# Altium schematic sheet Filter_Section.SchDoc
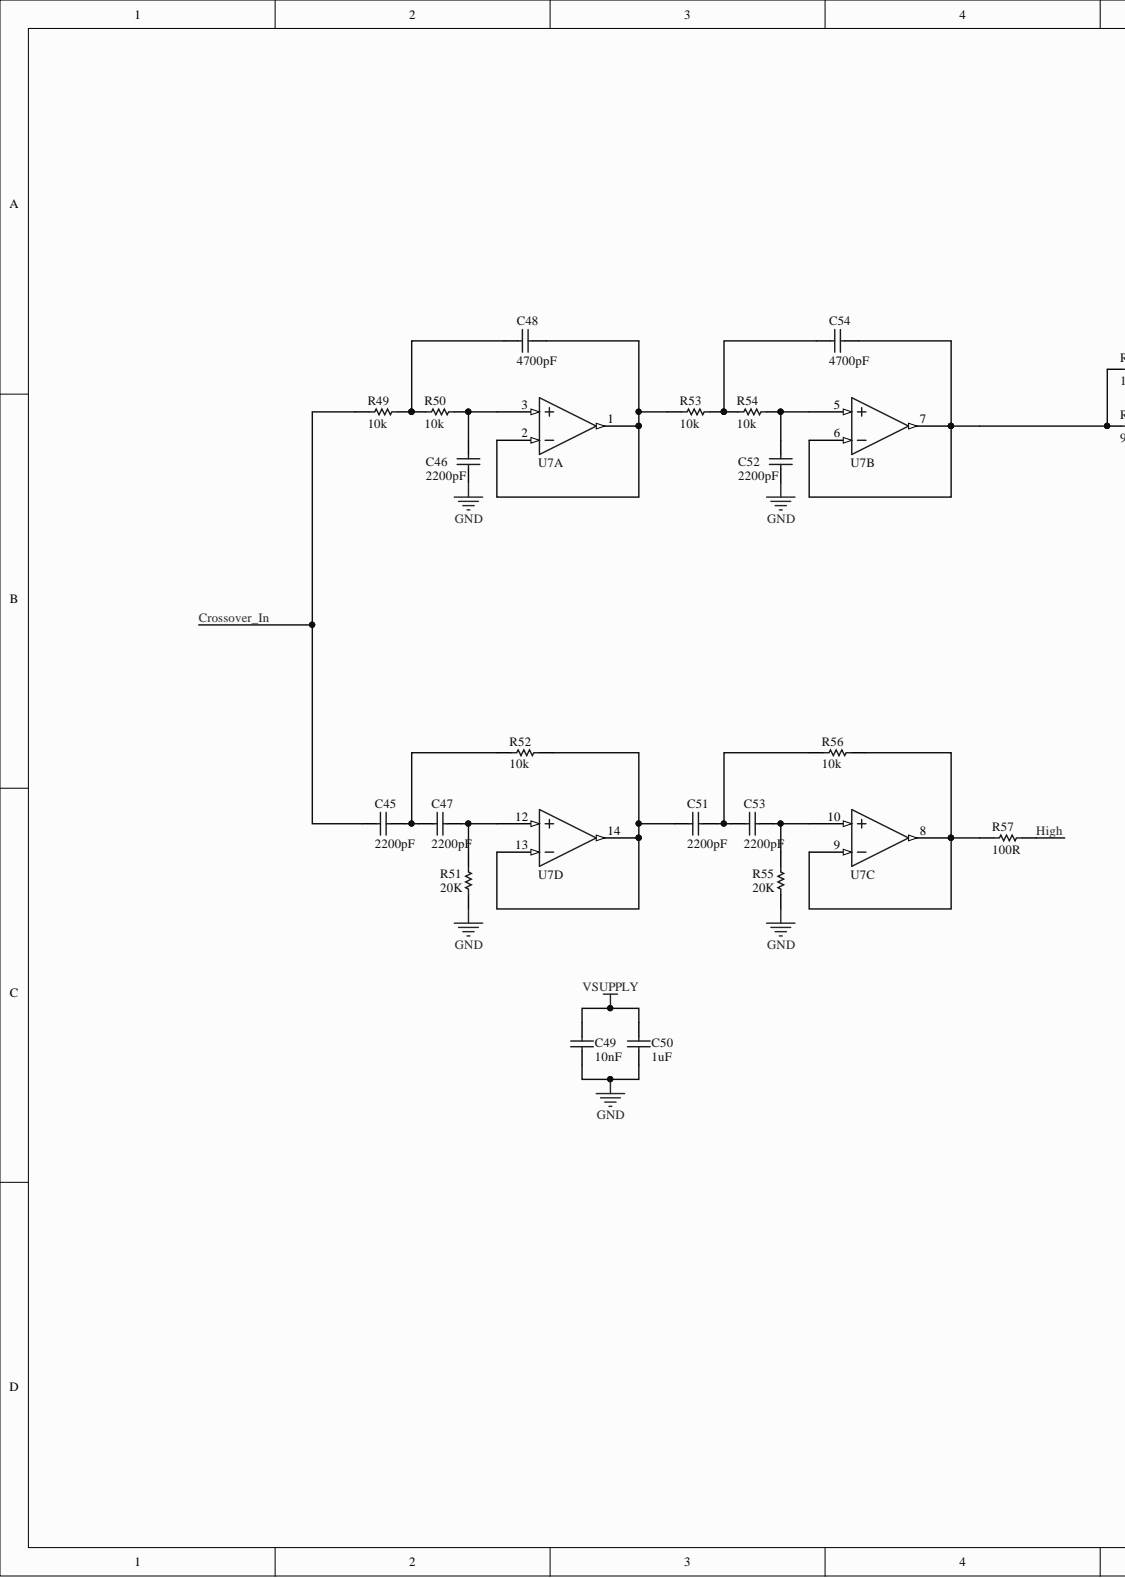
[diagram p0: Filter_Section.SchDoc, left]
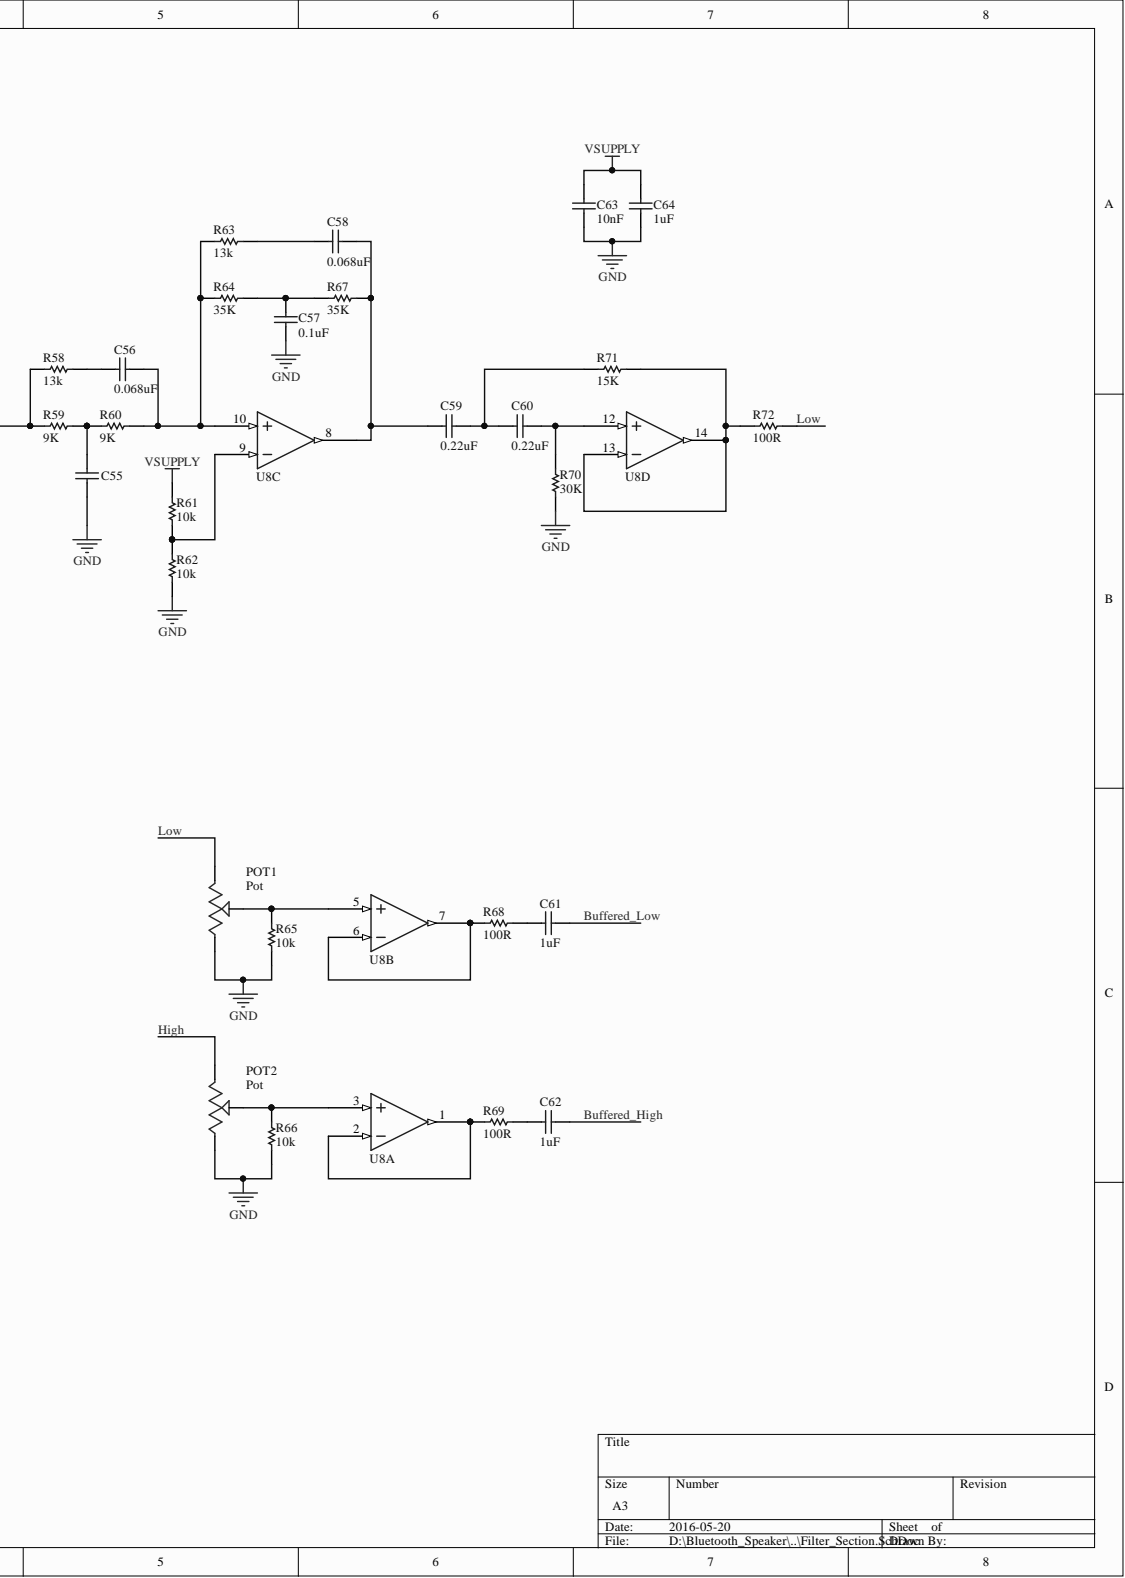
[diagram p1: Filter_Section.SchDoc, right]

## Parts
C45  2200pF  [CAPC1608X09N]
C46  2200pF  [CAPC1608X09N]
C47  2200pF  [CAPC1608X09N]
C48  4700pF  [CAPC1608X09N]
C49  10nF  [CAPC1608X09N]
C50  1uF  [CAPC1608X09N]
C51  2200pF  [CAPC1608X09N]
C52  2200pF  [CAPC1608X09N]
C53  2200pF  [CAPC1608X09N]
C54  4700pF  [CAPC1608X09N]
C55  0.39uF  [CAP Radial 5mm]  (value not drawn)
C56  0.068uF  [CAPC3216X18N]
C57  0.1uF  [CAPC3216X18N]
C58  0.068uF  [CAPC3216X18N]
C59  0.22uF  [CAP Radial 5mm]
C60  0.22uF  [CAP Radial 5mm]
C61  1uF  [CAP Radial 5mm]
C62  1uF  [CAP Radial 5mm]
C63  10nF  [CAPC1608X09N]
C64  1uF  [CAPC1608X09N]
POT1  Pot  [70543-0002]
POT2  Pot  [70543-0002]
R49  10k  [0603]
R50  10k  [0603]
R51  20K  [0603]
R52  10k  [0603]
R53  10k  [0603]
R54  10k  [0603]
R55  20K  [0603]
R56  10k  [0603]
R57  100R  [0603]
R58  13k  [0603]
R59  9K  [0603]
R60  9K  [0603]
R61  10k  [0603]
R62  10k  [0603]
R63  13k  [0603]
R64  35K  [0603]
R65  10k  [0603]
R66  10k  [0603]
R67  35K  [0603]
R68  100R  [0603]
R69  100R  [0603]
R70  30K  [0603]
R71  15K  [0603]
R72  100R  [0603]
U7  OPA4134UA  [SOIC127P600X175-14N]  (value not drawn)  (parts A,B,C,D)
U8  OPA4134UA  [SOIC127P600X175-14N]  (value not drawn)  (parts A,B,C,D)

## Nets
GND: C46.1 C49.1 C50.1 C52.1 C55.1 C57.2 C63.1 C64.1 POT1.3 POT2.3 R51.1 R55.2 R62.1 R65.1 R66.1 R70.1 U7.11 U8.11
VSUPPLY: C49.2 C50.2 C63.2 C64.2 R61.2 U7.4 U8.4
BUFFERED_HIGH: C62.2
BUFFERED_LOW: C61.2
CROSSOVER_IN: C45.1 R49.1
HIGH: POT2.1 R57.2
LOW: POT1.1 R72.2
NetC45_2: C45.2 C47.1 R52.1
NetC46_2: C46.2 R50.2 U7.3
NetC47_2: C47.2 R51.2 U7.12
NetC48_1: C48.1 R49.2 R50.1
NetC48_2: C48.2 R53.1 U7.1 U7.2
NetC51_1: C51.1 R52.2 U7.13 U7.14
NetC51_2: C51.2 C53.1 R56.1
NetC52_2: C52.2 R54.2 U7.5
NetC53_2: C53.2 R55.1 U7.10
NetC54_1: C54.1 R53.2 R54.1
NetC54_2: C54.2 R58.1 R59.1 U7.6 U7.7
NetC55_2: C55.2 R59.2 R60.1
NetC56_1: C56.1 R58.2
NetC56_2: C56.2 R60.2 R63.1 R64.1 U8.10
NetC57_1: C57.1 R64.2 R67.1
NetC58_1: C58.1 R63.2
NetC58_2: C58.2 C59.1 R67.2 U8.8
NetC59_2: C59.2 C60.1 R71.1
NetC60_2: C60.2 R70.2 U8.12
NetC61_1: C61.1 R68.2
NetC62_1: C62.1 R69.2
NetPOT1_2: POT1.2 R65.2 U8.5
NetPOT2_2: POT2.2 R66.2 U8.3
NetR56_2: R56.2 R57.1 U7.8 U7.9
NetR61_1: R61.1 R62.2 U8.9
NetR68_1: R68.1 U8.6 U8.7
NetR69_1: R69.1 U8.1 U8.2
NetR71_2: R71.2 R72.1 U8.13 U8.14

## Library data of the parts
C45-C47 C51-C53 = CAP CER 2200pF 0603: Manufacturer: Murata; Rating: C0G; Tolerance: 1%; Voltage: 50V; Component Kind: Standard; Digikey Part Number: 490-7768-1-ND; Value: 2200pF; Library Name: Speaker Library.SchLib
C48 C54 = CAP CER 4700pF 0603: Manufacturer: TDK; Rating: C0G; Tolerance: 5%; Voltage: 100V; Component Kind: Standard; Digikey Part Number: 445-172684-1-ND; Value: 4700pF; Library Name: Speaker Library.SchLib
C49 C63 = CAP CER 10nF 0603: Bypass caps; Manufacturer: Murata; Component Kind: Standard; Digikey Part Number: 490-9666-1-ND; Value: 10nF; Library Name: Speaker Library.SchLib
C50 C64 = CAP CER 1uF 0603: Voltage: 35V; Component Kind: Standard; Digikey Part Number: 490-10487-1-ND; Value: 1uF; Library Name: Speaker Library.SchLib
C55 = CAP 0.39uF Radial 5mm: Film cap in LT; Manufacturer: EPCOS (TDK); Manufacturer P/N: B32529C105J; Voltage: 63V; Component Kind: Standard; Digikey Part Number: 495-4846-1-ND; Value: 0.39uF; Library Name: Speaker Library.SchLib
C56 C58 = CAP CER 0.068uF 1206: Rating: C0G; Tolerance: 5%; Voltage: 100V; Component Kind: Standard; Digikey Part Number: 445-172693-1-ND; Package: 1206; Value: 0.068uF; Library Name: Speaker Library.SchLib
C57 = CAP CER 0.1uF 1206: LT capacitor; Rating: C0G; Tolerance: 5%; Voltage: 100V; Component Kind: Standard; Digikey Part Number: 445-172694-1-ND; Package: 1206; Value: 0.1uF; Library Name: Speaker Library.SchLib
C59 C60 = CAP 0.22uF Radial 5mm: Film cap in crossover; Manufacturer: EPCOS (TDK); Voltage: 100V; Component Kind: Standard; Digikey Part Number: 495-4985-1-ND; Value: 0.22uF; Library Name: Speaker Library.SchLib
C61 C62 = CAP 1uF Radial 5mm: Audio coupling cap; Manufacturer: EPCOS (TDK); Manufacturer P/N: B32529C105J; Voltage: 63V; Component Kind: Standard; Digikey Part Number: 495-1119-ND; Value: 1uF; Library Name: Speaker Library.SchLib
R49 R50 R52-R54 R56 R61 R62 R65 R66 = RES 10K 0603: Manufacturer: Yageo; Manufacturer Part Number: RC0603FR-0710KL; Power: 0.1W; Tolerance: 1%; Component Kind: Standard; Digikey Part Number: 311-10.0KHRCT-ND; Temperature: 155dC; Type: RES; Value: 10k; Library Name: Speaker Library.SchLib
R51 R55 = RES 20K 0603: Power: 0.1W; Tolerance: 1%; Component Kind: Standard; Value: 20K; Library Name: Speaker Library.SchLib
R57 R68 R69 R72 = RES 100R 0603: Power: 0.25W; Tolerance: 1%; Component Kind: Standard; Digikey Part Number: 541-100SACT-ND; Type: RES; Value: 100R; Library Name: Speaker Library.SchLib
R58 R63 = RES 13K 0603: Tolerance: 1%; Component Kind: Standard; Type: RES; Value: 13k; Library Name: Speaker Library.SchLib
R59 R60 = RES 9K 0603: Tolerance: 1%; Component Kind: Standard; Type: RES; Value: 9K; Library Name: Speaker Library.SchLib
R64 R67 = RES 35K 0603: Tolerance: 1%; Component Kind: Standard; Type: RES; Value: 35K; Library Name: Speaker Library.SchLib
R70 = RES 30K 0603: Tolerance: 1%; Component Kind: Standard; Type: RES; Value: 30K; Library Name: Speaker Library.SchLib
R71 = RES 15K 0603: Tolerance: 1%; Component Kind: Standard; Type: RES; Value: 15K; Library Name: Speaker Library.SchLib
U7 U8 = OPA4134UA: Quad OPAx134 Operational Amplifier; Component Kind: Standard; Library Name: Speaker Library.SchLib
POT1 POT2 = Pot: Component Kind: Standard; Library Name: Speaker Library.SchLib
